# Altium schematic sheet Sheet1.SchDoc
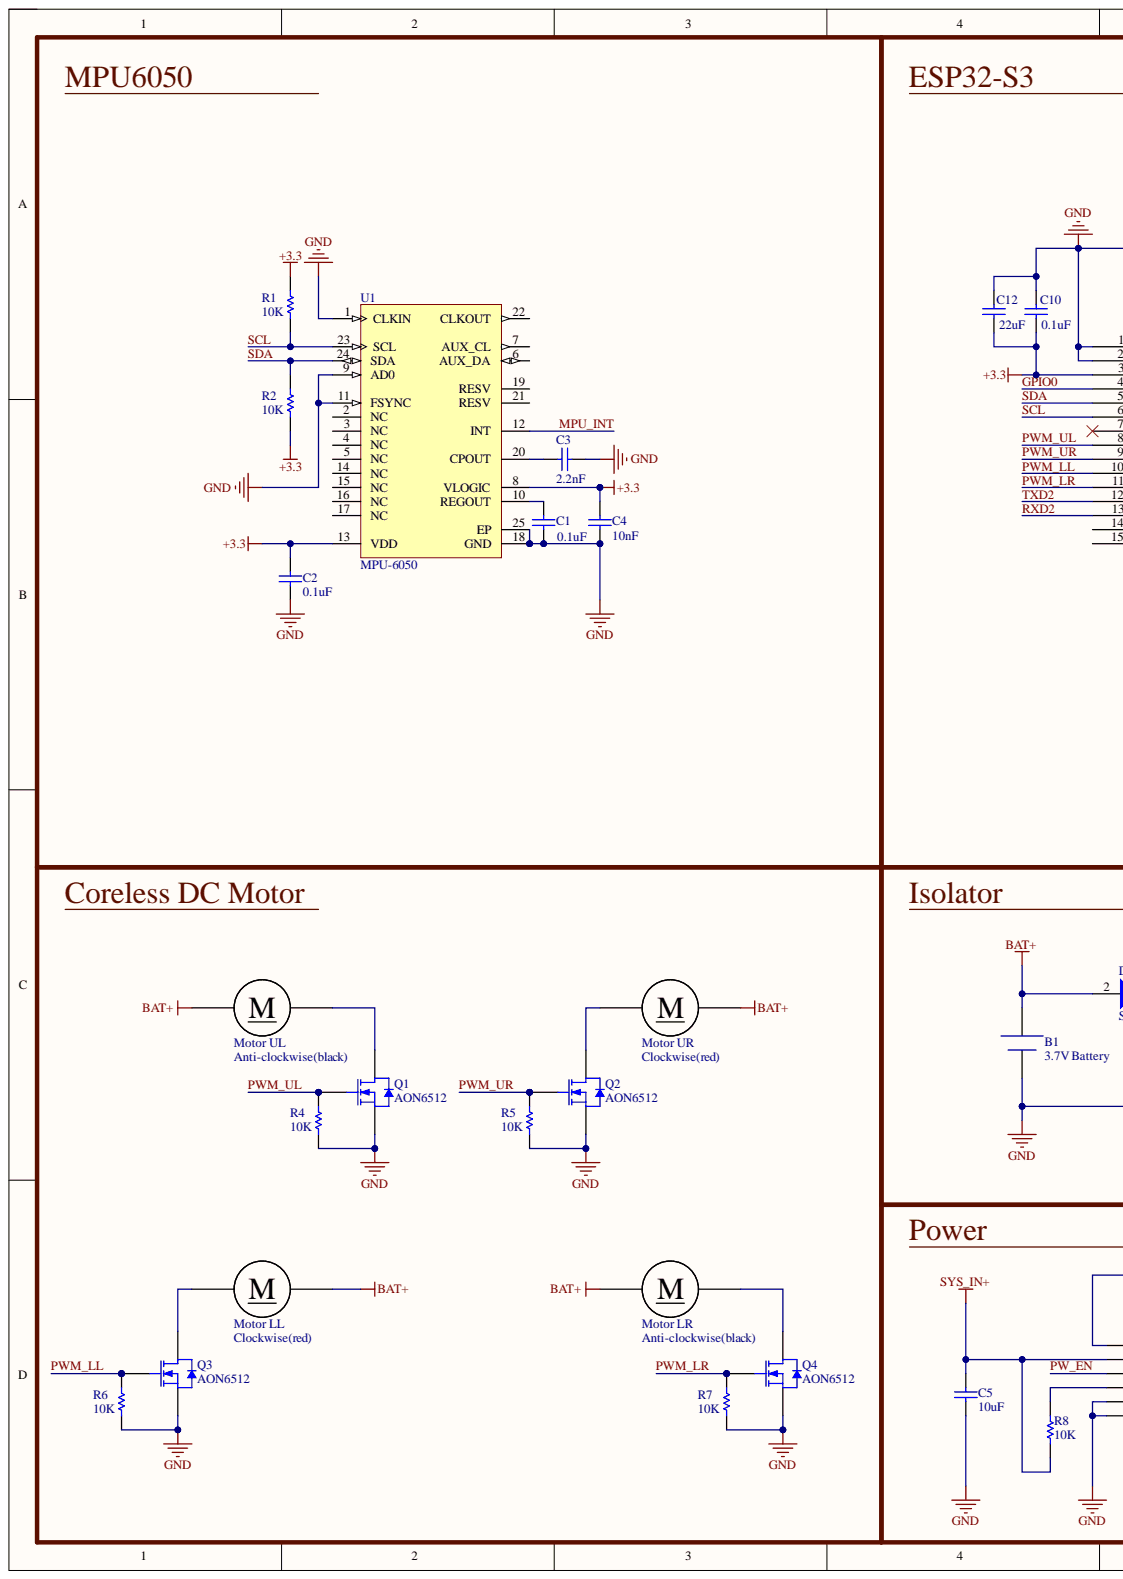
[diagram p0: Sheet1.SchDoc, left]
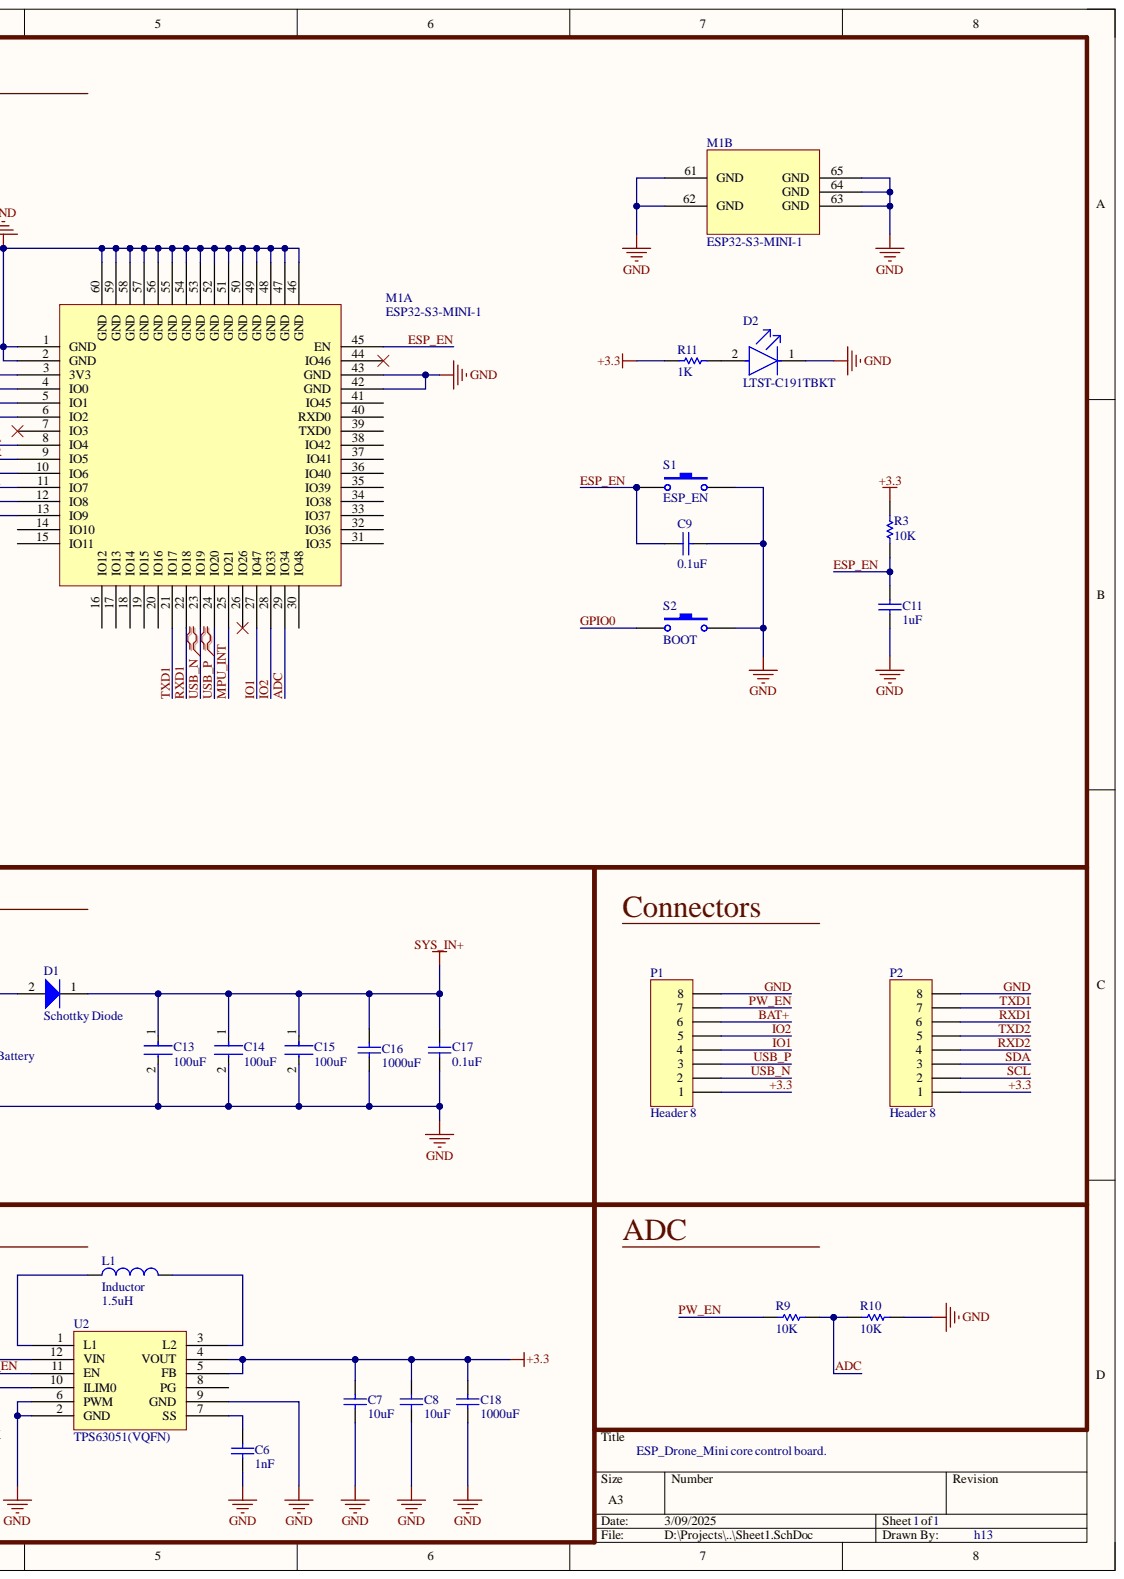
[diagram p1: Sheet1.SchDoc, right]

## Parts
B1  3.7V Battery  [51005 SMT 2Pin]
C1  0.1uF  [CAPC2013X94X50LL20T25]
C2  0.1uF  [CAPC2013X94X50LL20T25]
C3  2.2nF  [CAPC2013X94X50LL20T25]
C4  10nF  [CAPC2013X94X50LL20T25]
C5  10uF  [CAPC2013X94X50LL20T25]
C6  1nF  [CAPC2013X94X50LL20T25]
C7  10uF  [CAPC2013X94X50LL20T25]
C8  10uF  [CAPC2013X94X50LL20T25]
C9  0.1uF  [CAPC2013X94X50LL20T25]
C10  0.1uF  [CAPC2013X94X50LL20T25]
C11  1uF  [CAPC2013X94X50LL20T25]
C12  22uF  [CAPC2013X94X50LL20T25]
C13  100uF  [FP-C1210C107M9PACTU-MFG]
C14  100uF  [FP-C1210C107M9PACTU-MFG]
C15  100uF  [FP-C1210C107M9PACTU-MFG]
C16  value 1000uF  [SMD-EC8X8X10]  (hidden comment: Cap)
C17  0.1uF  [CAPC2013X94X50LL20T25]
C18  value 1000uF  [SMD-EC8X8X10]  (hidden comment: Cap)
D1  Schottky Diode  [ONSC-SOD-123-2-425-04_V]
D2  LTST-C191TBKT  [FP-LTST-C191TBKT-MFG]
L1  Inductor  value 1.5uH  [4020]
M1  ESP32-S3-MINI-1  [PCBComponent_1]  (parts A,B)
Motor_LL  Motor DC  [HDR1X2]  (value not drawn)
Motor_LR  Motor DC  [HDR1X2]  (value not drawn)
Motor_UL  Motor DC  [HDR1X2]  (value not drawn)
Motor_UR  Motor DC  [HDR1X2]  (value not drawn)
P1  Header 8  [HDR1X8]
P2  Header 8  [HDR1X8]
Q1  AON6512  [AON6512]
Q2  AON6512  [AON6512]
Q3  AON6512  [AON6512]
Q4  AON6512  [AON6512]
R1  10K  [RESC2013X60X40LL20T25_A]
R2  10K  [RESC2013X60X40LL20T25_A]
R3  10K  [RESC2013X60X40LL20T25_A]
R4  10K  [RESC2013X60X40LL20T25_A]
R5  10K  [RESC2013X60X40LL20T25_A]
R6  10K  [RESC2013X60X40LL20T25_A]
R7  10K  [RESC2013X60X40LL20T25_A]
R8  10K  [RESC2013X60X40LL20T25_A]
R9  10K  [RESC2013X60X40LL20T25_A]
R10  10K  [RESC2013X60X40LL20T25_A]
R11  1K  [RESC2013X60X40LL20T25_A]
S1  ESP_EN  [Button SMT 3.9*2.9]
S2  BOOT  [Button SMT 3.9*2.9]
U1  MPU-6050  [IS-QFN-24_V]
U2  TPS63051(VQFN)  [RMW0012A]

## Nets
SYS_IN+: C5.2 C13.1 C14.1 C15.1 C16.1 C17.1 D1.1 R8.1 U2.12(VIN)
+3.3: C2.1 C4.1 C7.2 C8.2 C10.2 C12.2 C18.1 M1.3(3V3) P1.1 P2.1 R1.2 R2.2 R3.1 R11.1 U1.8(VLOGIC) U1.13(VDD) U2.4(VOUT) U2.5(FB)
ADC: M1.29(IO34) R9.1 R10.2
BAT+: B1.1 D1.2 Motor_LL.2 Motor_LR.1 Motor_UL.1 Motor_UR.2 P1.6
ESP_EN: C9.2 C11.1 M1.45(EN) R3.2 S1.1
GND: B1.2 C1.2 C2.2 C3.1 C4.2 C5.1 C6.1 C7.1 C8.1 C9.1 C10.1 C11.2 C12.1 C13.2 C14.2 C15.2 C16.2 C17.2 C18.2 D2.1 M1.1(GND) M1.2(GND) M1.42(GND) M1.43(GND) M1.46(GND) M1.47(GND) M1.48(GND) M1.49(GND) M1.50(GND) M1.51(GND) M1.52(GND) M1.53(GND) M1.54(GND) M1.55(GND) M1.56(GND) M1.57(GND) M1.58(GND) M1.59(GND) M1.60(GND) M1.61(GND) M1.62(GND) M1.63(GND) M1.64(GND) M1.65(GND) P1.8 P2.8 Q1.3 Q2.3 Q3.3 Q4.3 R4.1 R5.1 R6.1 R7.1 R10.1 S1.2 S2.2 U1.1(CLKIN) U1.9(AD0) U1.11(FSYNC) U1.18(GND) U1.25(EP) U2.2(GND) U2.6(PWM) U2.9(GND)
GPIO0: M1.4(IO0) S2.1
IO1: M1.27(IO47) P1.4
IO2: M1.28(IO33) P1.5
MPU_INT: M1.25(IO21) U1.12(INT)
PW_EN: P1.7 R9.2 U2.11(EN)
PWM_LL: M1.10(IO6) Q3.1 R6.2
PWM_LR: M1.11(IO7) Q4.1 R7.2
PWM_UL: M1.8(IO4) Q1.1 R4.2
PWM_UR: M1.9(IO5) Q2.1 R5.2
RXD1: M1.22(IO18) P2.6
RXD2: M1.13(IO9) P2.4
SCL: M1.6(IO2) P2.2 R1.1 U1.23(SCL)
SDA: M1.5(IO1) P2.3 R2.1 U1.24(SDA)
TXD1: M1.21(IO17) P2.7
TXD2: M1.12(IO8) P2.5
USB_N: M1.23(IO19) P1.2
USB_P: M1.24(IO20) P1.3
NetC1_1: C1.1 U1.10(REGOUT)
NetC3_2: C3.2 U1.20(CPOUT)
NetC6_2: C6.2 U2.7(SS)
NetD2_2: D2.2 R11.2
NetL1_1: L1.1 U2.1(L1)
NetL1_2: L1.2 U2.3(L2)
NetMotor LL_1: Motor_LL.1 Q3.2
NetMotor LR_2: Motor_LR.2 Q4.2
NetMotor UL_2: Motor_UL.2 Q1.2
NetMotor UR_1: Motor_UR.1 Q2.2
NetR8_2: R8.2 U2.10(ILIM0)

Not connected: M1 7(IO3) 14(IO10) 15(IO11) 16(IO12) 17(IO13) 18(IO14) 19(IO15) 20(IO16) 26(IO26) 30(IO48) 31(IO35) 32(IO36) 33(IO37) 34(IO38) 35(IO39) 36(IO40) 37(IO41) 38(IO42) 39(TXD0) 40(RXD0) 41(IO45) 44(IO46); U1 2(NC) 3(NC) 4(NC) 5(NC) 6(AUX_DA) 7(AUX_CL) 14(NC) 15(NC) 16(NC) 17(NC) 19(RESV) 21(RESV) 22(CLKOUT); U2 8(PG)

## Library data of the parts
B1 = Battery: 3.7V; Component Kind: Standard; Library Name: Battery.SchLib
C1-C12 C17 = CMP-2007-04184-1: Manufacturer: AVX Interconnect / Elco; Part Number: 08051C222JAT2A; Component Kind: Standard; Library Name: Altium Content Vault
C13-C15 = CMP-2000-06018-2: CAP CER 100UF 6.3V X5R 1210; Dielectric Material: Ceramic; Dissipation Factor: 5%; Tolerance: 20%; Capacitance: 100uF; Case Code (Imperial): 1210; Case Code (Metric): 3225; Case/Package: 1210; Component Kind: Standard; Construction: Flat; Contact Plating: Tin; Depth: 2.5mm; Dielectric: X5R; Height: 2.5mm; Lead Free: Lead Free; Length: 3.2mm; Library Name: Altium Content Vault; Max Operating Temperature: 85°C; Min Operating Temperature: -55°C; Mount: Surface Mount; Number of Pins: 2; Package Quantity: 1; Packaging: Tape and Reel; Radiation Hardening: No; REACH SVHC: No SVHC; RoHS Compliant: Yes; Series: C; Termination: SMD/SMT; Thickness: 0.11inch; Voltage: 6.3V; Voltage Rating: 6.3V; Voltage Rating (DC): 6.3V; Width: 2.5mm
C16 C18 = Cap: Capacitor; Component Kind: Standard; LatestRevisionDate: 17-Jul-2002; LatestRevisionNote: Re-released for DXP Platform.; Library Name: Miscellaneous Devices.IntLib; PackageDescription: Radial Cap, Thru-Hole; 2 Leads; 0.3 in Pin Spacing; PackageReference: RAD-0.3; Published: 8-Jun-2000; Signal Integrity: Cap; Simulation: CAP; Publisher: Altium Limited
D1 = CMP-1055-00429-1: Schottky Barrier Diode, 2-Pin SOD-123, Pb-Free, Tape and Reel; Manufacturer: On Semiconductor; PartNumber: BAT54T1G; Configuration: Single; IFSM Max (A): 0.6; trr Max (ns): 5; Component Kind: Standard; ComponentLink1Description: Manufacturer URL; ComponentLink1URL: http://www.onsemi.com/; ComponentLink2Description: Datasheet; ComponentLink2URL: http://www.onsemi.cn/pub_link/Collateral/BAT54T1-D.PDF; ComponentLink3Description: Package Specification; ComponentLink3URL: http://www.onsemi.com/pub_link/Collateral/425-04.PDF; DatasheetVersion: Rev. 9; Library Name: Altium Content Vault; Mounting Technology: Surface Mount; PackageDescription: 2-Pin SOD, Body 1.6 x 2.69 mm; PackageReference: SOD-123-2-425-04; PackageVersion: Rev. G, 10/2009; Packing: Tape and Reel; RoHS: TRUE
D2 = CMP-22017-000008-1: LED BLUE CLEAR CHIP SMD; Dominant Wavelength: 475nm; Forward Current: 20mA; Forward Voltage: 3.8V; Lens Color: Colorless; Lens Style: Diffused; Luminous Intensity: 180mcd; Max Power Dissipation: 75mW; Number of Elements: 1; Power Dissipation: 76mW; Reverse Voltage: 5V; Viewing Angle: 130°; Wavelength: 468nm; Case Code (Imperial): 0603; Case Code (Metric): 1608; Case/Package: 0603; Color: Blue; Component Kind: Standard; Depth: 1.6mm; Height: 0.55mm; Illumination Color: Blue; Lead Free: Lead Free; Length: 0.8mm; Lens Transparency: Clear; Library Name: Altium Content Vault; Max Operating Temperature: 80°C; Min Operating Temperature: -20°C; Mount: Surface Mount; Number of LEDs: 1; Number of Pins: 2; Package Quantity: 1; Packaging: Tape and Reel; Radiation Hardening: No; Test Current: 20mA; Width: 0.8mm
L1 = Inductor: Code_IEC: 1005; Code_JEITA: 0402; Component Kind: Standard; Description: Inductor; LatestRevisionDate: 29-May-2009; LatestRevisionNote: IPC-7351 Footprint Added.; Library Name: Miscellaneous Devices.IntLib; PackageDescription: Chip Inductor; PackageReference: 0402-A; Published: 8-Jun-2000; Signal Integrity: Inductor; Simulation: INDUCTOR; Publisher: Altium Limited
P1 P2 = Header 8: Header, 8-Pin; Component Kind: Standard; LatestRevisionDate: 17-Jul-2002; LatestRevisionNote: Re-released for DXP Platform.; Library Name: Miscellaneous Connectors.IntLib; Signal Integrity: Connector; Publisher: Altium Limited
Q1-Q4 = CMP-1091-00211-1: N-Channel OptiMOS Power-Transistor, 60 V VDS, 100 A ID, -55 to 175 degC, PG-TO262-3-1, Tube, Green; Manufacturer: Infineon; PartNumber: IPI029N06N; Green: TRUE; ID (max): 100Â A; IDpuls (max): 400Â A; Polarity: N; Ptot (max): 136Â W; QG: 56Â nC; RDS (on) (max): 2.9Â mOhm; Rth: 1.1Â K/W; RthJA (max): 62 K/W; VDS (max): 60Â V; VGS(th) (max): 3.3 V; VGS(th) (min): 2.1Â V; Component Kind: Standard; ComponentLink1Description: Manufacturer URL; ComponentLink1URL: http://www.infineon.com/; ComponentLink2Description: Datasheet; ComponentLink2URL: http://www.infineon.com/dgdl/IPI029N06N_Rev2.2.pdf?folderId=db3a304313b8b5a60113cee8763b02d7&fileId=db3a30433727a44301372bab38f54926; ComponentLink3Description: Package Specification; ComponentLink3URL: http://www.infineon.com/dgdl/DS_IPX65R420CFD_2_4.pdf?folderId=db3a3043156fd5730115c736bcc70ff2&fileId=db3a30432f91014f012f9c293f1a7399; DatasheetVersion: Rev. 2.2; Halogen-free: TRUE; Library Name: Altium Content Vault; Mounting Technology: Through Hole; PackageDescription: 3-Pin Plastic (Green) Transistor Single Outline Package, 10.03 x 8.98 mm Body, 2.54 mm Pitch; PackageReference: PG-TO262-3-1; PackageVersion: Rev. 03, 05/2006; Packing: Tube; RthJC (max): 1.1Â K/W
R1-R11 = CMP-2001-00582-2: Metal Film Chip Resistor, High Reliability Type, 0.125 W, +/- 0.1%, -55 to 155 degC, 0805 (2012 Metric), RoHS, Tape and Reel; Brand: Panasonic; Power Rating: 125mW(1/8W); Resistance: 10kOhms; Temperature Coefficient: 25PPM/C; Voltage Rating: 100V; Case Code - in: 0805; Case Code - mm: 2012; Component Kind: Standard; Datasheet URL: https://industrial.panasonic.com/cdbs/www-data/pdf/RDM0000/AOA0000C307.pdf; Datasheet Version: 02/2016; Factory Pack Quantity: 5000; Height: 0.5mm; Length: 2mm; Library Name: Altium Content Vault; Manufacturer: Panasonic; Manufacturer URL: http://www.panasonic.com/; Mounting Technology: SurfaceMount; Operating Temperature Range: -55Cto+155C; Package / Case: 0805(2012metric); Package Description: 2-Pin Surface Mount Device, Body 2 x 1.25 mm; Package Reference: 0805; Packaging: Reel; Product: ThinFilmResistorsSMD; Product Category: ThinFilmResistors-SMD; RoHS: Details; Series: ERA-6A; Termination Style: SMD/SMT; Tolerance: 0.1%; Type: MetalFilmChipResistors; Width: 1.25mm
S1 S2 = SW-PB: Switch; Component Kind: Standard; LatestRevisionDate: 17-Jul-2002; LatestRevisionNote: Re-released for DXP Platform.; Library Name: Miscellaneous Devices.IntLib; PackageDescription: Switch; 2 Leads; PackageReference: SPST-2; Published: 24-Mar-1999; Publisher: Altium Limited
U1 = CMP-1753-00002-2: 3-Axis Gyroscope, Accelerometer and a Digital Motion Processor(TM) (DMP), 2.375 to 3.46 V, -40 to 85 degC, 24-Pin QFN, RoHS, Tape and Reel; Manufacturer: InvenSense; Dynamic Catalog: MPU-6000™Series; Featured Product: MPU-60506-Axis,IntegratedI²CSolution; Other Names: 1428-1007-2  MPU6050; Sensor Type: MotionTracking; Category: Sensors,Transducers; Component Kind: Standard; Datasheet URL: http://datasheet.octopart.com/MPU-6050-InvenSense-datasheet-14421344.pdf; Datasheet Version: Rev. 3.3, 05/2012; Family: Multifunction; Library Name: Altium Content Vault; Manufacturer URL: http://www.invensense.com/; Online Catalog: MPU-6000™Series; Output Type: Digital; Standard Package: 5,000; Unit PriceUSD: Digital
M1 = ESP32-S3-MINI-1: Component Kind: Standard; Library Name: ESP32-S3.SchLib
Motor_LL Motor_LR Motor_UL Motor_UR = Motor DC: Component Kind: Standard; Library Name: Motor.SchLib
U2 = TPS63051(VQFN): Component Kind: Standard; Library Name: TPS63051.SchLib
